# Altium schematic sheet PowerSupply.SchDoc(PowerSupply)
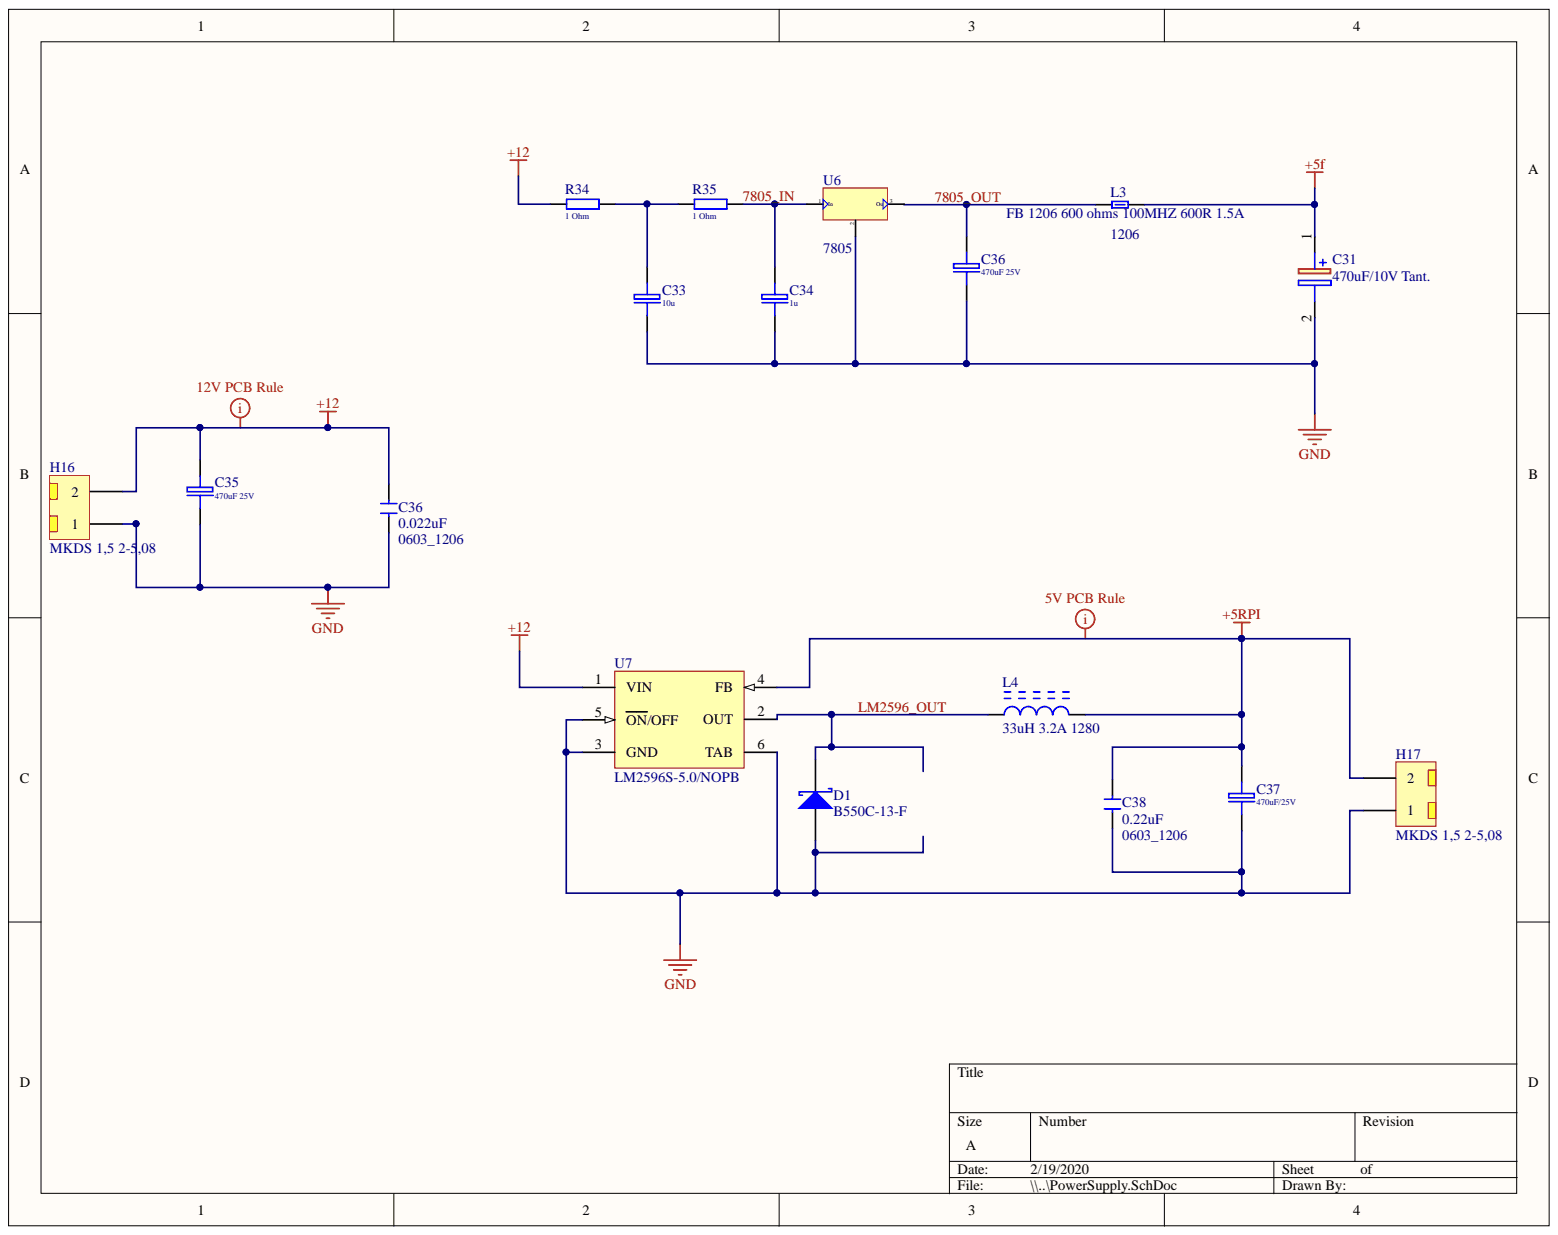
[diagram p0: PowerSupply.SchDoc]

## Parts
C31  470uF/10V Tant.  [SMD_2917]
C33  10u  [El-d5-h11-F2.54]
C34  1u  [El-d5-h11-F2.54]
C35  470uF 25V  [El-d8-h14-F3.81]
C36  0.022uF  value 0603_1206  [SMD_CHIP_CAP_CER_0603_TO_1206]
C36  470uF 25V  [El-d8-h14-F3.81]
C37  470uF/25V  [El-d8-h14-F3.81]
C38  0.22uF  value 0603_1206  [SMD_CHIP_CAP_CER_0603_TO_1206]
D1  B550C-13-F  [DIOD-SMC-2-A_V]
H16  MKDS 1,5 2-5,08  [MKDS 1,5 2-5,08]
H17  MKDS 1,5 2-5,08  [MKDS 1,5 2-5,08]
L3  FB 1206 600 ohms 100MHZ 600R 1.5A  value 1206  [SMD_CHIP_FERRITE_1206]
L4  33uH 3.2A 1280  [BOUR-SRR1280-2_V]
R34  1 Ohm  [R0.25-400]
R35  1 Ohm  [R0.25-400]
U6  7805  [TO 220_DISIPADOR_HORIZONTAL_2]
U7  LM2596S-5.0/NOPB  [KTT0005B_M]

## Nets
+5f: C31.1 L3.2
+5RPI: C37.1 C38.2 H17.2 L4.2 U7.4(FB)
+12: C35.1 C36.2 H16.2 R34.1 U7.1(VIN)
GND: C31.2 C33.2 C34.2 C35.2 C36.1 C36.2 C37.2 C38.1 D1.1 H16.1 H17.1 U6.2 U7.3(GND) U7.5(ON/OFF) U7.6(TAB)
7805_IN: C34.1 R35.2 U6.1
7805_OUT: C36.1 L3.1 U6.3
LM2596_OUT: D1.2 L4.1 U7.2(OUT)
NetC33_1: C33.1 R34.2 R35.1

## Library data of the parts
C31 = SMD_2917: T495X477K010ATE100; Manufacturer_Name: Kemet; Allied_Number: 70610894; Allied Price/Stock: https://www.alliedelec.com/kemet-t495x477k010ate100/70610894/; Component Kind: Standard; Datasheet Link: http://www.kemet.com/Lists/ProductCatalog/Attachments/261/KEM_T2009_T495.pdf; Height: 3.1mm; Library Name: SMDCapacitors.SchLib; Manufacturer_Part_Number: T495X477K010ATE100; Mouser Part Number: 80-T495X477K10ATE100; Mouser Price/Stock: https://www.mouser.com/Search/Refine.aspx?Keyword=80-T495X477K10ATE100; RS Part Number: 8015530P; RS Price/Stock: http://uk.rs-online.com/web/p/products/8015530P
C33 C34 = 10u-35V: 35V; Component Kind: Standard; Library Name: Cap-El.IntLib; Price: 0.04; Signal Integrity: C; Simulation: C
  C33: Value: 10u
  C34: Value: 1u
C35-C37 = 470u-25V: 25V; Component Kind: Standard; Library Name: Cap-El.IntLib; Price: 0.15; Signal Integrity: C; Simulation: C
  C35 C36: Value: 470uF 25V
  C37: Value: 470uF/25V
C36 C38 = SMD_CHIP_CAP_CER_0603_TO_1206: Component Kind: Standard; Description: SMD_CHIP_CAP_CER_0603_TO_1206; Library Name: SMDCapacitors.SchLib
D1 = CMP-2000-05906-1: Schottky Barrier Rectifier  , 50 V, 5 A, -55 to 150 degC, 2-Pin SMD, RoHS, Tape and Reel; Brand: DiodesIncorporated; Configuration: Single; If - Forward Current: 5A; Ifsm - Forward Surge Current: 100A; Ir - Reverse Current: 500uA; Unit Weight: 0.007408oz; Vf - Forward Voltage: 0.7V; Vrrm - Repetitive Reverse Voltage: 50V; Component Kind: Standard; Datasheet URL: http://www.diodes.com/_files/datasheets/ds13012.pdf; Datasheet Version: Rev. 17-2, 07/2015; Factory Pack Quantity: 3000; Height: 2.5mm; Length: 7.11mm; Library Name: Altium Content Vault; Manufacturer: DiodesIncorporated; Manufacturer URL: http://www.diodes.com/; Maximum Operating Temperature: +125C; Minimum Operating Temperature: -55C; Mounting Style: SMD/SMT; Mounting Technology: SurfaceMount; Operating Temperature Range: -55Cto+125C; Package / Case: SMC; Package Description: 2-Pin Surface Mount Device, Body 6.855 x 5.905 mm; Package Reference: SMC; Packaging: Reel; Product: SchottkyDiodes; Product Category: SchottkyDiodes&Rectifiers; RoHS: True; Series: B550; Technology: Si; Termination Style: SMD/SMT; Type: SchottkyBarrierRectifier; Width: 6.22mm
H16 H17 = MKDS 1,5 2-5,08: PCB terminal block, nominal current: 17.5 A, nom. voltage: 400 V, pitch: 5.08 mm; Component Kind: Standard; Library Name: PCBScrewTerminalBlock MKDS_1,5 XX-5,08.SchLib
L3 = CHIP_FERRITE_BEAD_INDUCTOR_1206: Chip Ferrite Bead Inductor; Component Kind: Standard; Library Name: SMDInductors.SchLib
L4 = CMP-2000-07148-1: Shielded SMD Power Inductor, 33 uH, +/- 20%, -40 to 125 degC, 2-Pin SMD, RoHS, Tape and Reel; Manufacturer: Bourns; PartNumber: SRR1280; Core Material: Ferrite; DC Current Max: 3.5A; DC Resistance Max: 57MOhm; Inductance: 33000nH; Maximum DC Resistance: 57mΩ; Maximum Self Resonant Frequency: 9.5MHz; Minimum Quality Factor: 28; Component Kind: Standard; Datasheet URL: http://www.bourns.com/docs/Product-Datasheets/SRR1280.pdf; Datasheet Version: Rev. 03/15, 03/2015; Library Name: Altium Content Vault; Manufacturer URL: http://www.bourns.com/; Maximum DC Current: 3.5A; Maximum Operating Temperature: +125°C; Minimum Operating Temperature: -40°C; Mounting Technology: SurfaceMount; Package Description: 2-Pin Surface Mount Device, Body 12.5 x 12.5 mm; Package Reference: SRR1280-2; Package URL: http://www.bourns.com/docs/Product-Datasheets/SRR1280.pdf; Series: SRR1280; Tolerance: 20%
R34 R35 = R-0.25W: 0.25W 5% 250V; Component Kind: Standard; Price: 0.01; Signal Integrity: Res; Simulation: Res; Value: 1 Ohm; Library Name: Rez-Axial Pot.IntLib
U7 = CMP-0069-00269-3: SIMPLE SWITCHER® Power Converter 150 KHz 3A Step-Down Voltage Regulator, 5-pin TO-263, Pb-Free; Manufacturer: Texas Instruments; PackageTopMarking: NSUZXYTTE#*LM2596S*-5.0 P+; PowerWise: N; Code_JEDEC: MO-169-AB; Component Kind: Standard; ComponentLink1Description: Datasheet URL; ComponentLink1URL: http://www.ti.com/general/docs/lit/getliterature.tsp?genericPartNumber=LM2596&fileType=pdf; CompType: Switcher; Library Name: Altium Content Vault; PackageDescription: TO-263, Molded, .400 x .390 x .180in, 5 Lead, .067in Pitch, Surface Mount; PackageReference: KTT0005B; PinCount: 5; RoHS: Y
U6 = 7805: Component Kind: Standard; Library Name: Power IC.IntLib; Price: 0.312
